# Altium schematic sheet Sheet4.SchDoc(Sheet4)
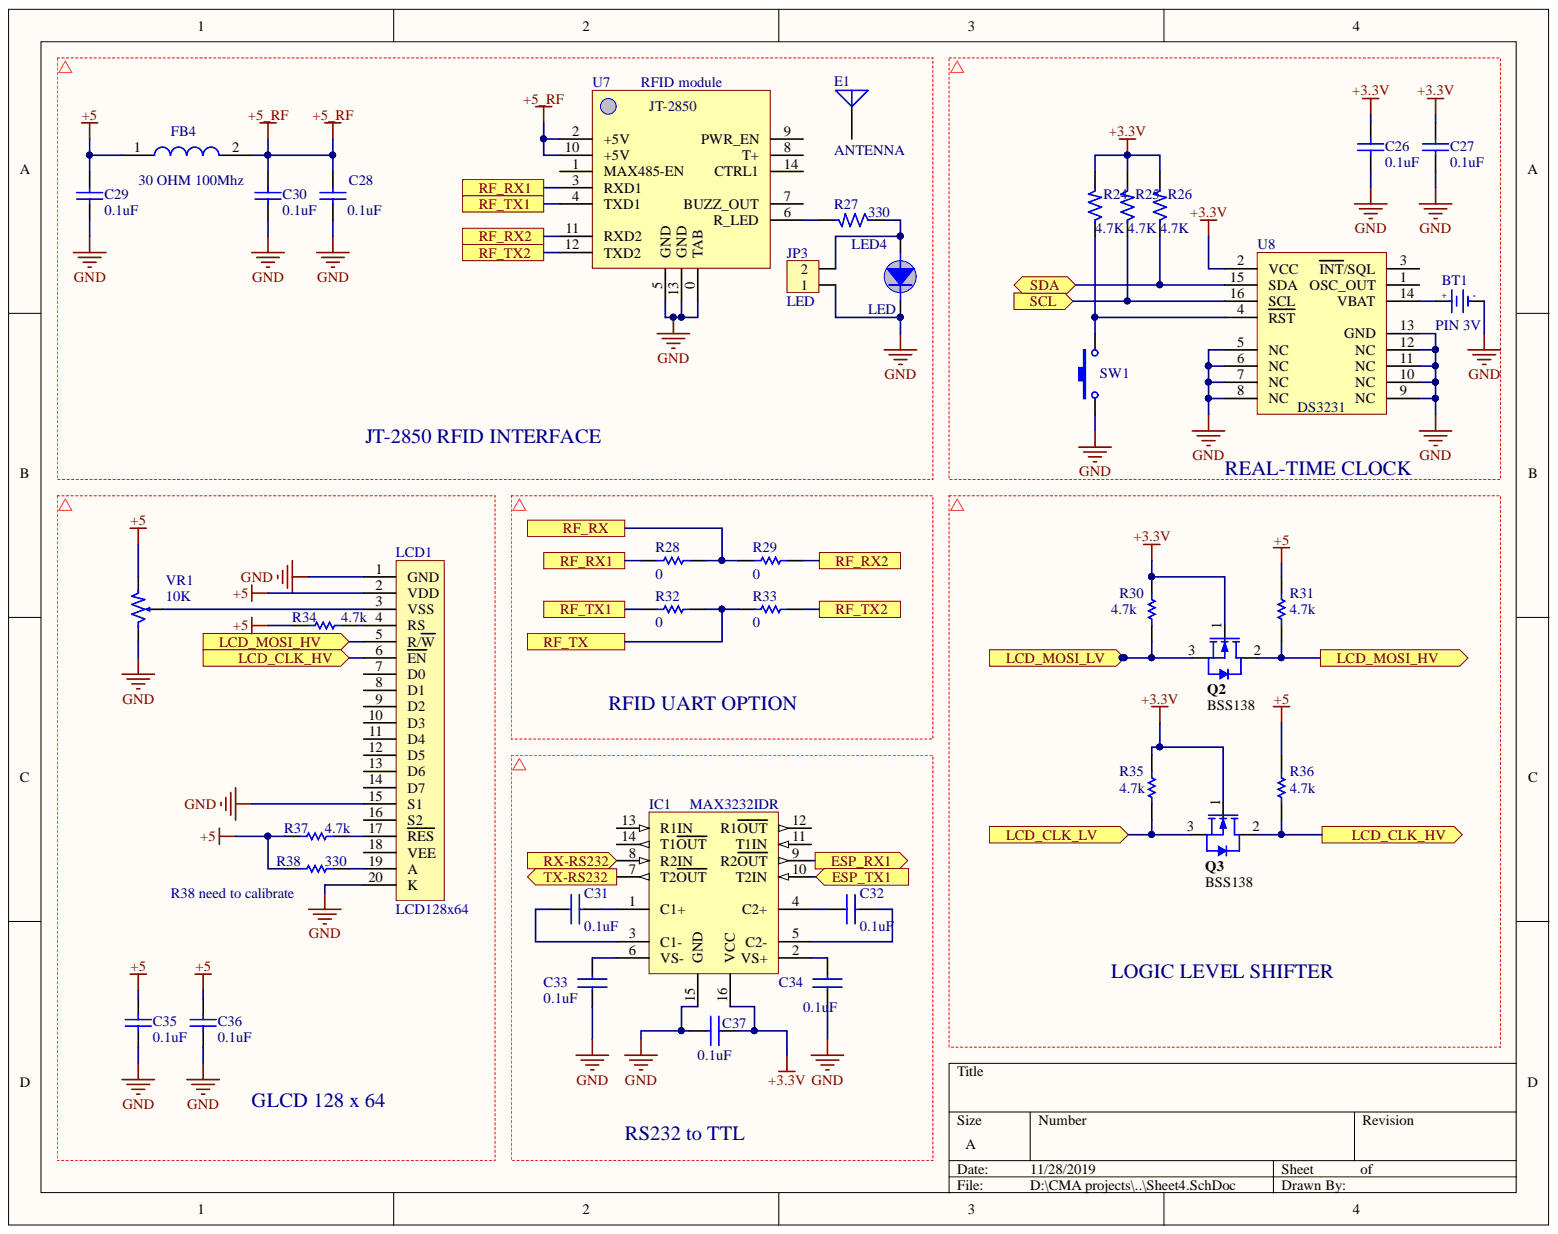
[diagram p0: Sheet4.SchDoc]

## Parts
BT1  PIN 3V  [CR1220 SMD]
C26  value 0.1uF  [0603]  (hidden comment: Capacitor Ceramic)
C27  value 0.1uF  [0603]  (hidden comment: Capacitor Ceramic)
C28  value 0.1uF  [0603]  (hidden comment: Capacitor Ceramic)
C29  value 0.1uF  [0603]  (hidden comment: Capacitor Ceramic)
C30  value 0.1uF  [0603]  (hidden comment: Capacitor Ceramic)
C31  0.1uF  [CN-SMD-0603]
C32  0.1uF  [CN-SMD-0603]
C33  0.1uF  [CN-SMD-0603]
C34  0.1uF  [CN-SMD-0603]
C35  value 0.1uF  [0603]  (hidden comment: Capacitor Ceramic)
C36  value 0.1uF  [0603]  (hidden comment: Capacitor Ceramic)
C37  0.1uF  [CN-SMD-0603]
E1  ANTENNA  [Ceramic Antenna 40x40mm]
FB4  30 OHM 100Mhz  [0805]
IC1  MAX3232IDR  [SO16]
JP3  LED  [CON2]
LCD1  LCD128x64  [LCD12864]
LED4  LED  [LED-SMD-0805]
Q2  BSS138  [SOT23-123]
Q3  BSS138  [SOT23-123]
R24  4.7K  [RES-SMD-0603]
R25  4.7K  [RES-SMD-0603]
R26  4.7K  [RES-SMD-0603]
R27  value 330  [RES-SMD-0603]  (hidden comment: 4.7K)
R28  0  [RES-SMD-0603]
R29  0  [RES-SMD-0603]
R30  4.7k  [RES-SMD-0603]
R31  4.7k  [RES-SMD-0603]
R32  0  [RES-SMD-0603]
R33  0  [RES-SMD-0603]
R34  4.7k  [RES-SMD-0603]
R35  4.7k  [RES-SMD-0603]
R36  4.7k  [RES-SMD-0603]
R37  4.7k  [RES-SMD-0603]
R38  330  [RES-SMD-0603]
SW1  SW-SMD  [SW-SMD]  (value not drawn)
U7  RFID module  [Module JT-2850]
U8  DS3231  [SOIC16_M]
VR1  10K  [VR]

## Ports
ESP_RX1, ESP_TX1, LCD_CLK_HV, LCD_CLK_LV, LCD_MOSI_HV, LCD_MOSI_LV, RF_RX, RF_RX1, RF_RX2, RF_TX, RF_TX1, RF_TX2, RX-RS232, SCL, SDA, TX-RS232

## Nets
+3.3V: C26.1 C27.1 C37.1 IC1.16(VCC) Q2.1 Q3.1 R24.2 R25.2 R26.2 R30.1 R35.1 U8.2(VCC)
+5: C29.1 C35.1 C36.1 FB4.1 LCD1.2(VDD) R31.1 R34.2 R36.1 R37.2 R38.2 VR1.1
+5_RF: C28.1 C30.1 FB4.2 U7.2(+5V) U7.10(+5V)
GND: BT1.2 C26.2 C27.2 C28.2 C29.2 C30.2 C33.1 C34.1 C35.2 C36.2 C37.2 IC1.15(GND) JP3.1 LCD1.1(GND) LCD1.15(S1) LCD1.20(K) LED4.2 SW1.1 U7.0(TAB) U7.5(GND) U7.13(GND) U8.5(NC) U8.6(NC) U8.7(NC) U8.8(NC) U8.9(NC) U8.10(NC) U8.11(NC) U8.12(NC) U8.13(GND) VR1.3
ESP_RX1: IC1.9(R2OUT) ; port ESP_RX1
ESP_TX1: IC1.10(T2IN) ; port ESP_TX1
LCD_CLK_HV: LCD1.6(EN) Q3.2 R36.2 ; port LCD_CLK_HV
LCD_CLK_LV: Q3.3 R35.2 ; port LCD_CLK_LV
LCD_MOSI_HV: LCD1.5(R/W) Q2.2 R31.2 ; port LCD_MOSI_HV
LCD_MOSI_LV: Q2.3 R30.2 ; port LCD_MOSI_LV
RF_RX: R28.2 R29.1 ; port RF_RX
RF_RX1: R28.1 U7.3(RXD1) ; port RF_RX1
RF_RX2: R29.2 U7.11(RXD2) ; port RF_RX2
RF_TX: R32.2 R33.1 ; port RF_TX
RF_TX1: R32.1 U7.4(TXD1) ; port RF_TX1
RF_TX2: R33.2 U7.12(TXD2) ; port RF_TX2
RX-RS232: IC1.8(R2IN) ; port RX-RS232
SCL: R25.1 U8.16(SCL) ; port SCL
SDA: R26.1 U8.15(SDA) ; port SDA
TX-RS232: IC1.7(T2OUT) ; port TX-RS232
NetBT1_1: BT1.1 U8.14(VBAT)
NetC31_1: C31.1 IC1.3(C1-)
NetC31_2: C31.2 IC1.1(C1+)
NetC32_1: C32.1 IC1.4(C2+)
NetC32_2: C32.2 IC1.5(C2-)
NetC33_2: C33.2 IC1.6(VS-)
NetC34_2: C34.2 IC1.2(VS+)
NetJP3_2: JP3.2 LED4.1 R27.1
NetLCD1_3: LCD1.3(VSS) VR1.2
NetLCD1_4: LCD1.4(RS) R34.1
NetLCD1_17: LCD1.17(RES) R37.1
NetLCD1_19: LCD1.19(A) R38.1
NetR24_1: R24.1 SW1.2 U8.4(RST)
NetR27_2: R27.2 U7.6(R_LED)

Not connected: E1 1; IC1 11(T1IN) 12(R1OUT) 13(R1IN) 14(T1OUT); LCD1 7(D0) 8(D1) 9(D2) 10(D3) 11(D4) 12(D5) 13(D6) 14(D7) 16(S2) 18(VEE); U7 1(MAX485-EN) 7(BUZZ_OUT) 8(T+) 9(PWR_EN) 14(CTRL1); U8 1(OSC_OUT) 3(INT/SQL)

## Library data of the parts
BT1 = PIN 3V: Battery 3VDC; Value: PIN 3V; Library Name: Cty1.SchLib; Component Kind: Standard
C26-C30 C35 C36 = CapSMD: Capacitor; Simulation: CAP; Signal Integrity: Cap; Published: 8-Jun-2000; PackageReference: RAD-0.3; Library Name: Cty1.SchLib; LatestRevisionNote: Re-released for DXP Platform.; LatestRevisionDate: 17-Jul-2002; Component Kind: Standard; Publisher: Altium Limited
C31-C34 C37 = CAP 0805: Right: 20; Bottom: 10; Library Name: Cty1.SchLib; Component Kind: Standard
E1 = ANTENNA: Generic Antenna; Library Name: Cty1.SchLib; Component Kind: Standard
FB4 = Ferrit Bead 30 OHM 100Mhz: FERRITE BEAD 30 OHM 100Mhz 0805; Library Name: Cty1.SchLib; Component Kind: Standard
IC1 = MAX232: Dual EIA-232 Driver/Receiver; Value: MAX232; Library Name: Cty1.SchLib; Component Kind: Standard
JP3 = Con2: Connector 2; Value: Connector 2; Component Kind: Standard; Library Name: syhaunguyen.SchLib
LCD1 = LCD128x64: LCD Graphic 128x64; Value: LCD 128x64; Component Kind: Standard; Library Name: syhaunguyen.SchLib
LED4 = LED_SMD 0805: Library Name: Cty1.SchLib; Component Kind: Standard
Q2 Q3 = 2N7002: N-channel Enhancement Mode Field-effect Transistor; Transistor Type: NPN; Status: Unreleased; Mounting Technology: Surface Mount; Library Name: Library.SchLib; Component Kind: Standard; Category: Transistor-FET
R24-R27 = Res: Resistor 1/4W; Library Name: Cty1.SchLib; Component Kind: Standard
  R24-R26: Value: 4.7K
R28-R38 = Res1: Resistor; Value: 10K; Simulation: RESISTOR; Signal Integrity: Res; Published: 8-Jun-2000; PackageReference: AXIAL-0.3; PackageDescription: Axial Device, Thru-Hole; 2 Leads; 0.3 in Pin Spacing; Library Name: Miscellaneous Devices.IntLib; LatestRevisionNote: Re-released for DXP Platform.; LatestRevisionDate: 17-Jul-2002; Component Kind: Standard; Publisher: Altium Limited
SW1 = SW-SMD: Switch; Published: 24-Mar-1999; PackageReference: SPST-2; Library Name: Cty1.SchLib; LatestRevisionNote: Re-released for DXP Platform.; LatestRevisionDate: 17-Jul-2002; Component Kind: Standard; Publisher: Altium Limited
U7 = Module JT-2850: Library Name: Cty1.SchLib; Component Kind: Standard
U8 = DS3231: Extremely Accurate I2C-Integrated  RTC/TCXO/Crystal; Library Name: Cty1.SchLib; Component Kind: Standard
VR1 = VR1: Var Resistor; Value: VR 10K; Component Kind: Standard; Library Name: BIENTRO.SchLib
